# Altium schematic sheet Sensor_Box_CAN_Switch.SchDoc
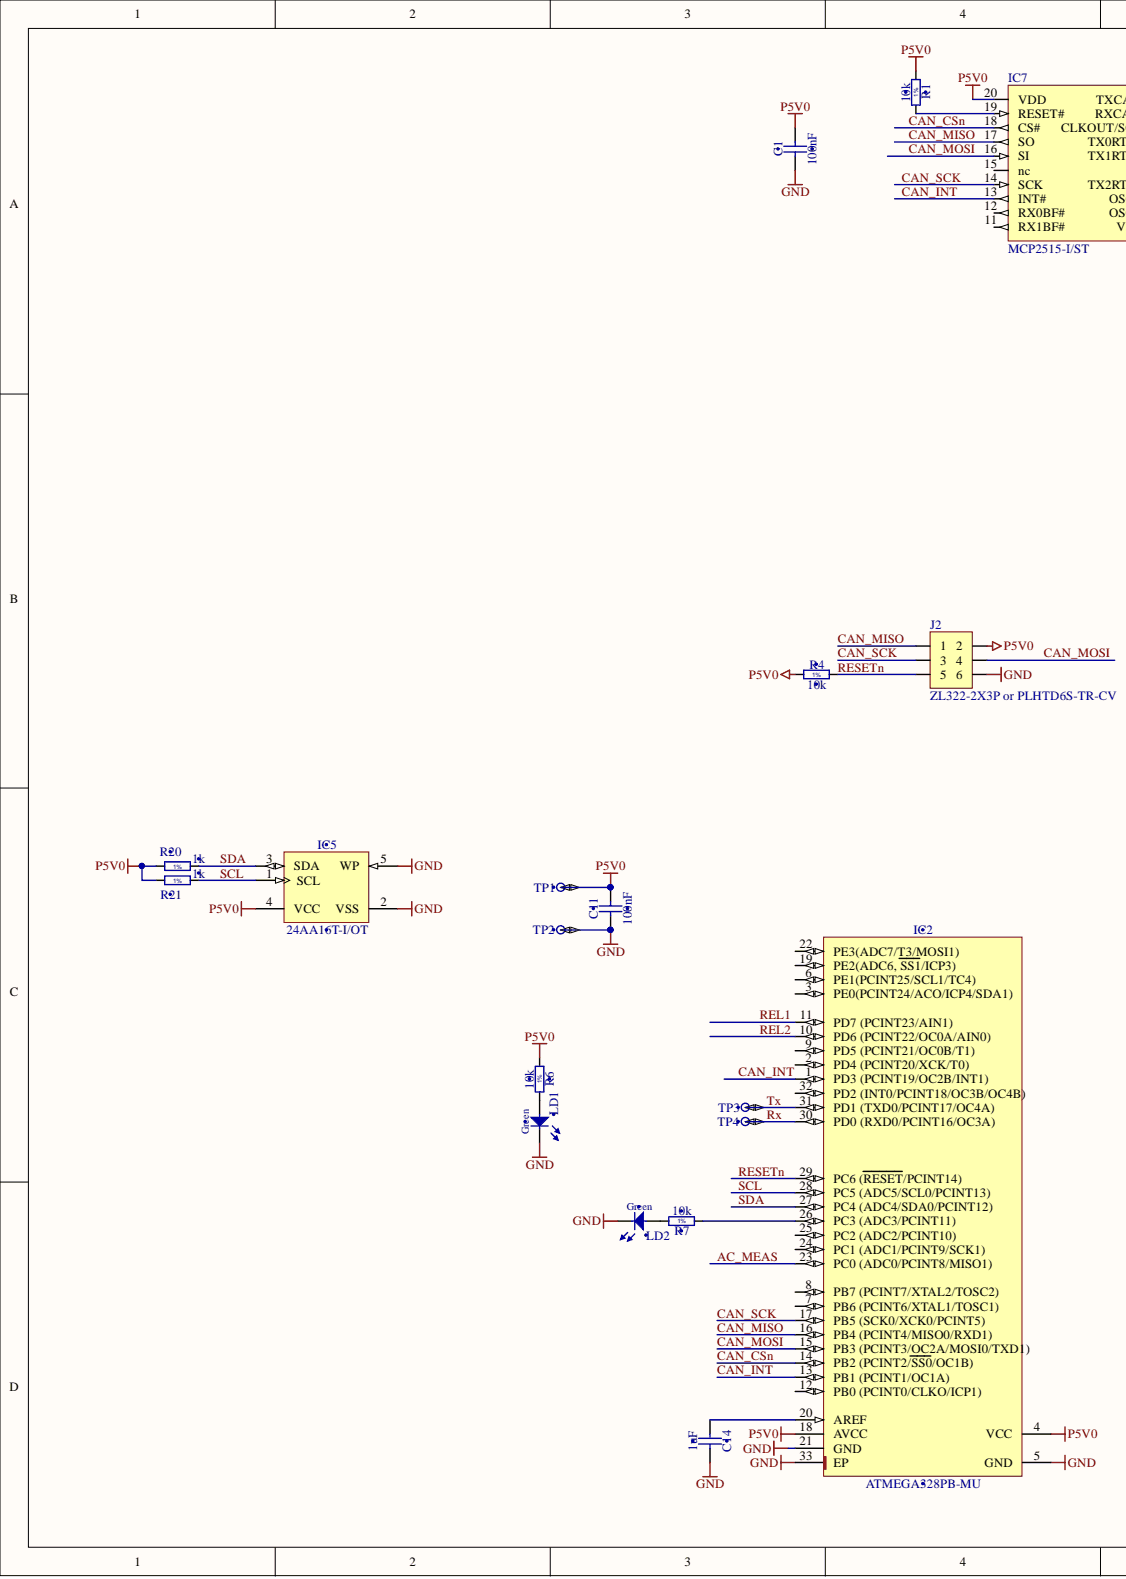
[diagram p0: Sensor_Box_CAN_Switch.SchDoc, left]
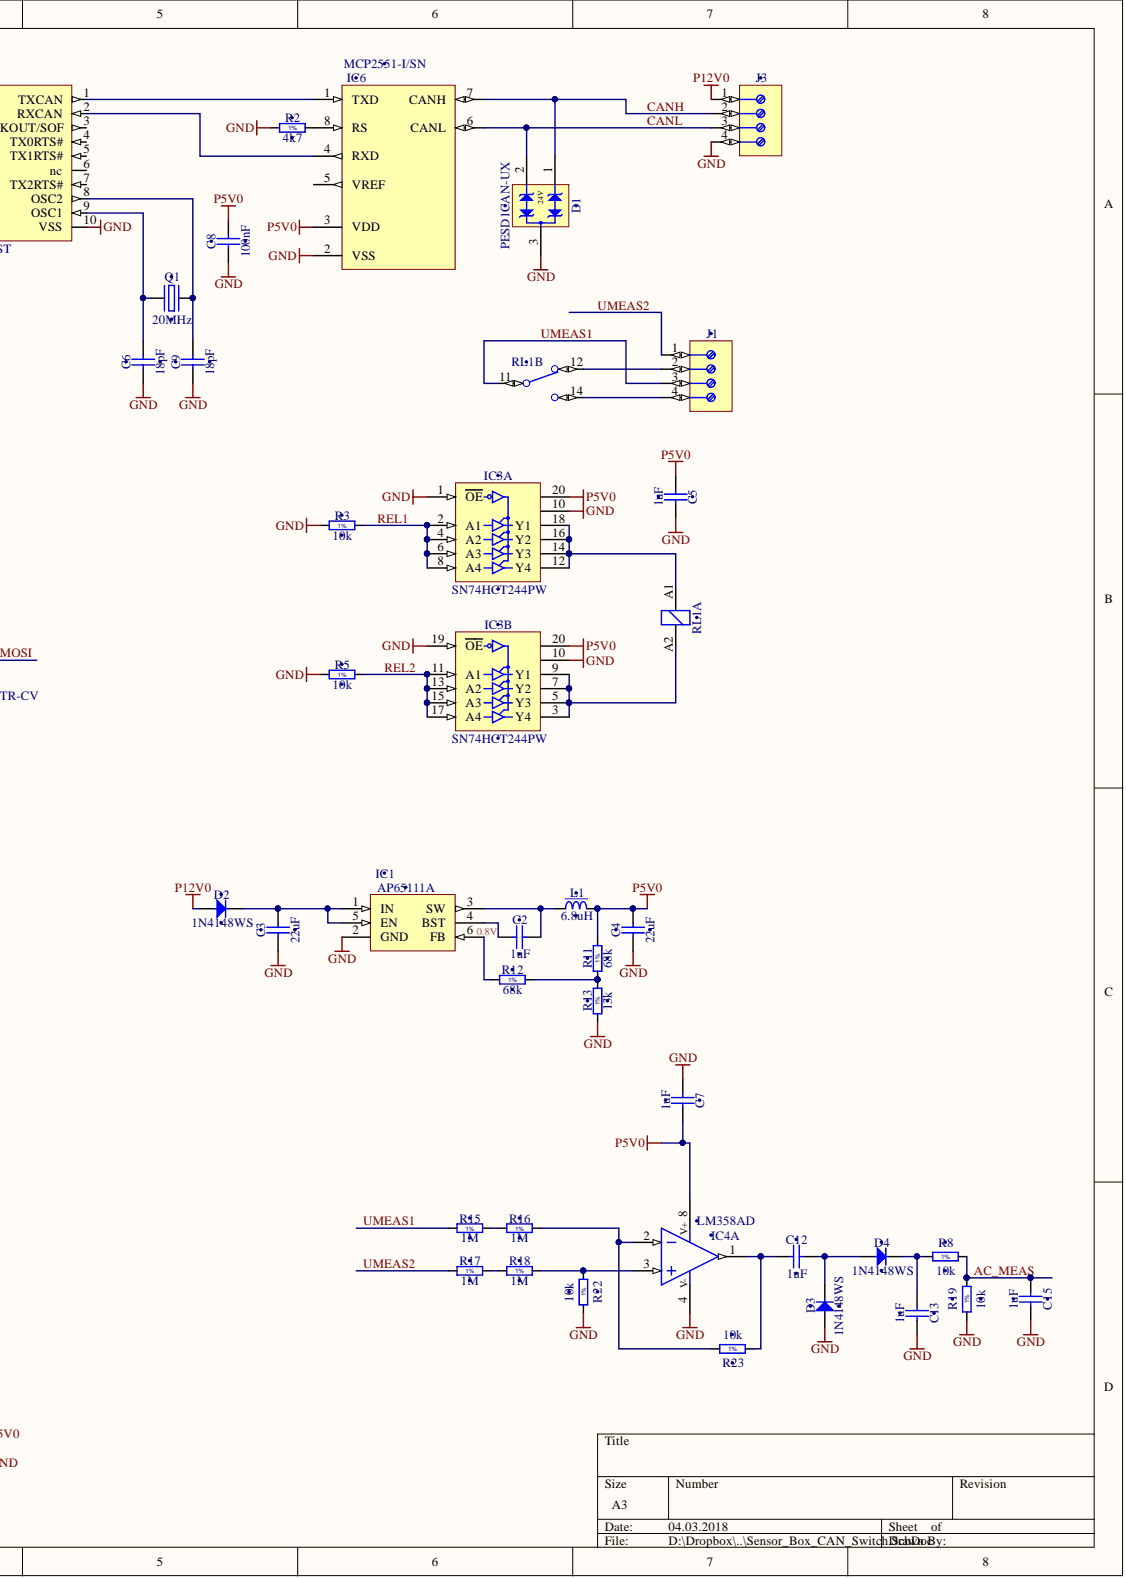
[diagram p1: Sensor_Box_CAN_Switch.SchDoc, right]

## Parts
C1  100nF  [CAPC1005X55N]
C2  1uF  [CAPC1608X87N]
C3  22uF  [CAPC1709X100N]
C4  22uF  [CAPC1709X100N]
C5  1uF  [CAPC1005X55N]
C6  18pF  [CAPC1005X55N]
C7  1uF  [CAPC1005X55N]
C8  100nF  [CAPC1005X55N]
C9  18pF  [CAPC1005X55N]
C11  100nF  [CAPC1005X55N]
C12  1uF  [CAPC1005X55N]
C13  1uF  [CAPC1005X55N]
C14  1uF  [CAPC1005X55N]
C15  1uF  [CAPC1005X55N]
D1  PESD1CAN-UX  Voltage: 24V  [SOT95P237X112-3N]
D2  1N4148WS  [SODFL2512X100N]
D3  1N4148WS  [SODFL2512X100N]
D4  1N4148WS  [SODFL2512X100N]
IC1  AP65111A  [SOT95P285X110-6N]
IC2  ATMEGA328PB-MU  [QFN50P500X500X100-33N-S325]
IC3  SN74HCT244PW  [SOP65P640X120-20N]  (parts A,B)
IC4  LM358AD  [SOIC127P600X175-8N]  (part A)
IC5  24AA16T-I/OT  [SOT95P280X145-5N]
IC6  MCP2551-I/SN  [SOIC127P600X175-8N]
IC7  MCP2515-I/ST  [SOP65P640X110-20N]
J1  Family  [TYCO_282836-4]  (value not drawn)
J2  ZL322-2X3P or PLHTD6S-TR-CV  [ZL322-2X3P]
J3  Family  [PHOENIX_1725672]  (value not drawn)
L1  6.8uH  [IND_WALSIN_WLPN3030]
LD1  Green  [LED_KINGBRIGHT_KPH-1608CGCK]
LD2  Green  [LED_KINGBRIGHT_KPH-1608CGCK]
Q1  20MHz  [XTAL1160X490X430]
R1  10k  [RESC1005X40N]
R2  4k7  [RESC1005X40N]
R3  10k  [RESC1005X40N]
R4  10k  [RESC1005X40N]
R5  10k  [RESC1005X40N]
R6  10k  [RESC1005X40N]
R7  10k  [RESC1005X40N]
R8  10k  [RESC1005X40N]
R11  68k  [RESC1005X40N]
R12  68k  [RESC1005X40N]
R13  13k  [RESC1005X40N]
R15  1M  [RESC3216X65N]
R16  1M  [RESC3216X65N]
R17  1M  [RESC3216X65N]
R18  1M  [RESC3216X65N]
R19  10k  [RESC1005X40N]
R20  1k  [RESC1005X40N]
R21  1k  [RESC1005X40N]
R22  10k  [RESC1005X40N]
R23  10k  [RESC1005X40N]
RL1  REL_TYCO_SCHRACK_PE014F05  [REL_TYCO_SCHRACK_PE]  (value not drawn)  (parts A,B)
TP1  Test Point  [TPS127]  (value not drawn)  (no BOM)
TP2  Test Point  [TPS127]  (value not drawn)  (no BOM)
TP3  Test Point  [TPS127]  (value not drawn)  (no BOM)
TP4  Test Point  [TPS127]  (value not drawn)  (no BOM)

## Nets
GND: C1.1 C3.1 C4.1 C5.2 C6.1 C7.1 C8.1 C9.1 C11.1 C13.2 C14.2 C15.2 D1.3 D3.2 IC1.2(GND) IC2.5(GND) IC2.21(GND) IC2.33(EP) IC3.1(OE) IC3.10(GND) IC3.19(OE) IC4.4 IC5.2(VSS) IC5.5(WP) IC6.2(VSS) IC7.10(VSS) J2.6 J3.4 LD1.1 LD2.1 R2.1 R3.1 R5.1 R13.1 R19.1 R22.2 TP2.1
P5V0: C1.2 C4.2 C5.1 C7.2 C8.2 C11.2 IC2.4(VCC) IC2.18(AVCC) IC3.20(VCC) IC4.8 IC5.4(VCC) IC6.3(VDD) IC7.20(VDD) J2.2 L1.2 R1.1 R4.1 R6.1 R11.2 R20.2 R21.2 TP1.1
P12V0: D2.2 J3.1
AC_MEAS: C15.1 IC2.23(PC0_(ADC0/PCINT8/MISO1)) R8.2 R19.2
CAN_CSn: IC2.14(PB2_(PCINT2/SS0/OC1B)) IC7.18(CS#)
CAN_INT: IC2.1(PD3_(PCINT19/OC2B/INT1)) IC2.13(PB1_(PCINT1/OC1A)) IC7.13(INT#)
CAN_MISO: IC2.16(PB4_(PCINT4/MISO0/RXD1)) IC7.17(SO) J2.1
CAN_MOSI: IC2.15(PB3_(PCINT3/OC2A/MOSI0/TXD1)) IC7.16(SI) J2.4
CAN_SCK: IC2.17(PB5_(SCK0/XCK0/PCINT5)) IC7.14(SCK) J2.3
CANH: D1.1 IC6.7(CANH) J3.2
CANL: D1.2 IC6.6(CANL) J3.3
REL1: IC2.11(PD7_(PCINT23/AIN1)) IC3.2(A1) IC3.4(A2) IC3.6(A3) IC3.8(A4) R3.2
REL2: IC2.10(PD6_(PCINT22/OC0A/AIN0)) IC3.11(A1) IC3.13(A2) IC3.15(A3) IC3.17(A4) R5.2
RESETn: IC2.29(PC6_(RESET/PCINT14)) J2.5 R4.2
Rx: IC2.30(PD0_(RXD0/PCINT16/OC3A)) TP4.1
SCL: IC2.28(PC5_(ADC5/SCL0/PCINT13)) IC5.1(SCL) R21.1
SDA: IC2.27(PC4_(ADC4/SDA0/PCINT12)) IC5.3(SDA) R20.1
Tx: IC2.31(PD1_(TXD0/PCINT17/OC4A)) TP3.1
UMEAS1: J1.3 R15.1 RL1.11
UMEAS2: J1.1 R17.1
NetC2_1: C2.1 IC1.4(BST)
NetC2_2: C2.2 IC1.3(SW) L1.1
NetC3_2: C3.2 D2.1 IC1.1(IN) IC1.5(EN)
NetC6_2: C6.2 IC7.9(OSC1) Q1.1
NetC9_2: C9.2 IC7.8(OSC2) Q1.2
NetC12_1: C12.1 IC4.1 R23.1
NetC12_2: C12.2 D3.1 D4.2
NetC13_1: C13.1 D4.1 R8.1
NetC14_1: C14.1 IC2.20(AREF)
NetIC1_6: IC1.6(FB) R12.1
NetIC2_26: IC2.26(PC3_(ADC3/PCINT11)) R7.1
NetIC3_3: IC3.3(Y4) IC3.5(Y3) IC3.7(Y2) IC3.9(Y1) RL1.A2
NetIC3_12: IC3.12(Y4) IC3.14(Y3) IC3.16(Y2) IC3.18(Y1) RL1.A1
NetIC4_2: IC4.2 R16.2 R23.2
NetIC4_3: IC4.3 R18.2 R22.1
NetIC6_1: IC6.1(TXD) IC7.1(TXCAN)
NetIC6_4: IC6.4(RXD) IC7.2(RXCAN)
NetIC6_8: IC6.8(RS) R2.2
NetIC7_19: IC7.19(RESET#) R1.2
NetJ1_2: J1.2 RL1.12
NetJ1_4: J1.4 RL1.14
NetLD1_2: LD1.2 R6.2
NetLD2_2: LD2.2 R7.2
NetR11_1: R11.1 R12.2 R13.2
NetR15_2: R15.2 R16.1
NetR17_2: R17.2 R18.1

Not connected: IC2 2(PD4_(PCINT20/XCK/T0)) 3(PE0(PCINT24/ACO/ICP4/SDA1)) 6(PE1(PCINT25/SCL1/TC4)) 7(PB6_(PCINT6/XTAL1/TOSC1)) 8(PB7_(PCINT7/XTAL2/TOSC2)) 9(PD5_(PCINT21/OC0B/T1)) 12(PB0_(PCINT0/CLKO/ICP1)) 19(PE2(ADC6,_SS1/ICP3)) 22(PE3(ADC7/T3/MOSI1)) 24(PC1_(ADC1/PCINT9/SCK1)) 25(PC2_(ADC2/PCINT10)) 32(PD2_(INT0/PCINT18/OC3B/OC4B)); IC6 5(VREF); IC7 3(CLKOUT/SOF) 4(TX0RTS#) 5(TX1RTS#) 6(nc) 7(TX2RTS#) 11(RX1BF#) 12(RX0BF#) 15(nc)

## Library data of the parts
C1 C8 C11 = CC0402_100NF_16V_10%_X5R: Non-polarised capacitor; Manufacturer: GENERIC; Manufacturer1 Part Number: GRM155R61C104KA88D; Part Description: SMD Multilayer Chip Ceramic Capacitor; Database Table Name: Capacitors SMD; TC: X5R; Tolerance: ±10%; Voltage: 16V; Case: 0402; Component Kind: Standard; Component Type: Standard; CreateDate: 10.12.2013; HelpURL: \\cern.ch\dfs\Applications\Altium\Datasheets\CC0402_X5R_MURATA_GRM.pdf; LatestRevisionDate: 10.12.2013; Library Name: Capacitors.DbLib; Manufacturer1 ComponentHeight: 0.55mm; Manufacturer1 Example: MURATA; Manufacturer Part Number: CC0402_100NF_16V_10%_X5R; Mounted: Yes; Part Number: CC0402_100NF_16V_10%_X5R; Pin Count: 2; Sense: No; SMD: Yes; Socket: No; Status: None; Value: 100nF; Author: CERN DEM JLC
C2 = CC0603_1UF_16V_10%_X7R: Non-polarised capacitor; Manufacturer: GENERIC; Manufacturer1 Part Number: 223878615663; Part Description: SMD Multilayer Chip Ceramic Capacitor; Database Table Name: Capacitors SMD; TC: X7R; Tolerance: ±10%; Voltage: 16V; Case: 0603; Component Kind: Standard; Component Type: Standard; CreateDate: 03.12.2007; HelpURL: \\cern.ch\dfs\Applications\Altium\Datasheets\CC0603_X7R_PHYCOMP.pdf; LatestRevisionDate: 17.04.2015; Library Name: Capacitors.DbLib; Manufacturer1 ComponentHeight: 0.9mm; Manufacturer1 Example: YAGEO PHYCOMP; Manufacturer Part Number: CC0603_1uF_16V_10%_X7R; Mounted: Yes; Part Number: CC0603_1UF_16V_10%_X7R; Pin Count: 2; Sense: No; SMD: Yes; Socket: No; Status: None; Value: 1uF; Author: CERN DEM JLC
C3 C4 = CC0603_22UF_6.3V_20%_X5R: Non-polarised capacitor; Manufacturer: GENERIC; Manufacturer1 Part Number: C1608X5R0J226M080AC; Part Description: SMD Multilayer Chip Ceramic Capacitor; Database Table Name: Capacitors SMD; TC: X5R; Tolerance: ±20%; Voltage: 6.3V; Case: 0603; Component Kind: Standard; Component Type: Standard; CreateDate: 16.12.2013; HelpURL: \\cern.ch\dfs\Applications\Altium\Datasheets\CC0603_X5R_TDK_C.pdf; LatestRevisionDate: 13.09.2016; Library Name: Capacitors.DbLib; Manufacturer1 ComponentHeight: 1mm; Manufacturer1 Example: TDK; Manufacturer Part Number: CC0603_22UF_6.3V_20%_X5R; Mounted: Yes; Part Number: CC0603_22UF_6.3V_20%_X5R; Pin Count: 2; Sense: No; SMD: Yes; Socket: No; Status: None; Value: 22uF; Author: CERN DEM JLC
C5 C7 C12-C15 = CC0402_1UF_16V_10%_X5R: Non-polarised capacitor; Manufacturer: GENERIC; Manufacturer1 Part Number: C1005X5R1C105KT; Part Description: SMD Multilayer Chip Ceramic Capacitor; Database Table Name: Capacitors SMD; TC: X5R; Tolerance: ±10%; Voltage: 16V; Case: 0402; Component Kind: Standard; Component Type: Standard; CreateDate: 25.10.2012; HelpURL: \\cern.ch\dfs\Applications\Altium\Datasheets\CC0402_X5R_TDK_C.pdf; LatestRevisionDate: 25.10.2012; Library Name: Capacitors.DbLib; Manufacturer1 ComponentHeight: 0.55mm; Manufacturer1 Example: TDK; Manufacturer Part Number: CC0402_1UF_16V_10%_X5R; Mounted: Yes; Part Number: CC0402_1UF_16V_10%_X5R; Pin Count: 2; Sense: No; SMD: Yes; Socket: No; Status: Preferred; Value: 1uF; Author: CERN DEM JLC
C6 C9 = CC0402_18PF_50V_5%_C0G: Non-polarised capacitor; Manufacturer: GENERIC; Manufacturer1 Part Number: C0402C180J5GACTU; Part Description: SMD Multilayer Chip Ceramic Capacitor; Database Table Name: Capacitors SMD; TC: C0G/NP0; Tolerance: ±5%; Voltage: 50V; Case: 0402; Component Kind: Standard; Component Type: Standard; CreateDate: 04.10.2016; HelpURL: \\cern.ch\dfs\Applications\Altium\Datasheets\CC0805_C0G_KEMET_(Commercial Grade).pdf; LatestRevisionDate: 04.10.2016; Library Name: Capacitors.DbLib; Manufacturer1 ComponentHeight: 0.55mm; Manufacturer1 Example: KEMET; Manufacturer Part Number: CC0402_18PF_50V_5%_C0G; Mounted: Yes; Part Number: CC0402_18PF_50V_5%_C0G; Pin Count: 2; Sense: No; SMD: Yes; Socket: No; Status: Preferred; Value: 18pF; Author: CERN DEM JLC
D1 = (no symbol name): TVS Diode for CAN; Manufacturer: Nexperia; Bonding: No; Power: 400W; PressFit: No; Case: SOT-23; ComponentHeight: 1.12mm; Component Kind: Standard; Component Type: Standard; CreateDate: 03.09.2014; Device: PESD1CAN-UX; HelpURL: \\cern.ch\dfs\Applications\Altium\Datasheets\SM712.TCT.pdf; LatestRevisionDate: 13.01.2015; Library Name: LIBRARY_SCH.SCHLIB; Manufacturer Part Number: PESD1CAN-UX; Mounted: Yes; PackageDescription: SOT, 0.95mm, pitch; 3 pin,1.30mm W X 2.92mm L X 1.12mm H body, IPC Medium Density; Part Description: TVS Diode for CAN; Part Number: PESD1CAN-UX; Pin Count: 3; Sense: No; SMD: Yes; Socket: No; Author: CERN DEM JLC
D2-D4 = 1N4148WS: Diode; Manufacturer: FAIRCHILD SEMICONDUCTOR; Part Description: Small Signal Diodes; Bonding: No; Database Table Name: Diodes; Power: 150mA; PressFit: No; Voltage: 75V; Case: SOD-323F; ComponentHeight: 1mm; Component Kind: Standard; Component Type: Standard; CreateDate: 12.05.2011; Device: 1N4148WS; HelpURL: \\cern.ch\dfs\Applications\Altium\Datasheets\1N4148WS.pdf; LatestRevisionDate: 12.05.2011; Library Name: ICs And Semiconductors.DbLib; Manufacturer Part Number: 1N4148WS; Mounted: Yes; PackageDescription: SODFL Diode; 1.70mm W X 1.25mm L X 1.00mm H body, IPC Medium Density; Part Number: 1N4148WS; Pin Count: 2; Sense: No; SMD: Yes; Socket: No; Author: CERN DEM JLC
IC1 = (no symbol name): LIGHT LOAD IMPROVED 1.5A SYNCH DC/DC BUCK CONVERTER; Manufacturer: DIODES INCORPORATED; Bonding: No; PressFit: No; Case: SOT26; ComponentHeight: 1.45mm; Component Kind: Standard; Component Type: Standard; CreateDate: 10.07.2015; Device: AP65111A; HelpURL: \\cern.ch\dfs\Applications\Altium\Datasheets\AP133-WG-7.pdf; LatestRevisionDate: 10.07.2015; Library Name: LIBRARY_SCH.SCHLIB; Manufacturer Part Number: AP65111A; Mounted: Yes; PackageDescription: SOT23, 0.95mm pitch; 6 pin, 1.6mm W X 3.0mm L X 1.45mm H body, IPC Medium Density; Part Description: LIGHT LOAD IMPROVED 1.5A SYNCH DC/DC BUCK CONVERTER; Part Number: AP65111A; Pin Count: 5; Sense: No; SMD: Yes; Socket: No; Author: GK
IC2 = (no symbol name): 8-bit AVR 20MHz Microcontroller With 32K Bytes In-System  Programmable  Flash; Manufacturer: ATMEL; Part Description: 8-bit AVR Microcontroller, 32KB Flash, 1KB EEPROM, 2KB SRAM, 32-pin MLF, Industrial Grade (-40°C to 85°C); Bonding: No; PressFit: No; Case: QFN32; ComponentHeight: 1mm; Component Kind: Standard; Component Type: Standard; CreateDate: 2011-02-08; Device: ATMEGA328PB-MU; HelpURL: \\cern.ch\dfs\Applications\Altium\Datasheets\ATMEGA328P-MU.pdf; LatestRevisionDate: 2014-07-02; Library Name: LIBRARY_SCH.SCHLIB; Manufacturer Part Number: ATMEGA328PB-MU; Mounted: Yes; PackageDescription: QFN, (MO-220-VHHD-2) 0.50mm pitch,square; 8 pin X 8 pin, 5mm X 5mm X 1.00mm H body (w/thermal tab 3.25 X 3.25mm),  IPC Medium Density; Part Number: ATMEGA328PB-MU; Pin Count: 33; Sense: No; SMD: Yes; Socket: No; Author: GK
IC3 = SN74HCT244PW: Octal Buffer/Driver with 3-State Ouputs; Manufacturer: TEXAS INSTRUMENTS; Part Description: Octal Buffer And Line Driver with 3-State Ouputs; Bonding: No; Database Table Name: Standard Logic; PressFit: No; Case: TSSOP20; ComponentHeight: 1.2mm; Component Kind: Standard; Component Type: Standard; CreateDate: 11.10.2011; Device: SN74HCT244PW; HelpURL: \\cern.ch\dfs\Applications\Altium\Datasheets\SN74HCT244PW.pdf; LatestRevisionDate: 11.10.2011; Library Name: ICs And Semiconductors.DbLib; Manufacturer Part Number: SN74HCT244PW; Mounted: Yes; PackageDescription: SOP, 20-Leads, Body 6.5x4.4mm, Pitch 0.65mm, IPC Medium Density; Part Number: SN74HCT244PW; Pin Count: 20; Sense: No; SMD: Yes; Socket: No; Author: CERN DEM JLC
IC4 = LM358AD: Dual Operational Amplifier; Manufacturer: TEXAS INSTRUMENTS; Bonding: No; Database Table Name: Operational Amplifiers; PressFit: No; Case: SOIC8; ComponentHeight: 1.75mm; Component Kind: Standard; Component Type: Standard; CreateDate: 02.12.2008; Device: LM358AD; HelpURL: \\cern.ch\dfs\Applications\Altium\Datasheets\LM358AD.pdf; LatestRevisionDate: 13.04.2010; Library Name: ICs And Semiconductors.DbLib; Manufacturer Part Number: LM358AD; Mounted: Yes; PackageDescription: SOIC 8, Pitch 1.27mm - Body 4x5mm, IPC Medium Density; Part Description: Dual Operational Amplifier; Part Number: LM358AD; Pin Count: 8; Sense: No; SMD: Yes; Socket: No; Author: CERN DEM JLC
IC5 = (no symbol name): 1.7V-5.5V, 2K I2C Serial EEPROM With EUI-48 Node Identity; Manufacturer: MICROCHIP TECHNOLOGY; Part Description: 1.7V-5.5V, 16K I2C Serial EEPROM; Bonding: No; PressFit: No; Case: SOT23-5; ComponentHeight: 1.45mm; Component Kind: Standard; Component Type: Standard; CreateDate: 31.03.2016; Device: 24AA16T-I/OT; HelpURL: \\cern.ch\dfs\Applications\Altium\Datasheets\24AA02E48T-I-OT.pdf; LatestRevisionDate: 31.03.2016; Library Name: LIBRARY_SCH.SCHLIB; Manufacturer Part Number: 24AA16T-I/OT; Mounted: Yes; PackageDescription: SOT, 0.95mm pitch; 5 pin, 1.60mm W X 2.90mm L X 1.45mm H body, IPC Medium Density; Part Number: 24AA16T-I/OT; Pin Count: 5; Sense: No; SMD: Yes; Socket: No; Author: GK
IC6 = (no symbol name): High-Speed CAN Transceiver, 8-Pin SOIC, Industrial Temperature; Manufacturer: MICROCHIP TECHNOLOGY; Bonding: No; PressFit: No; Case: SOIC8; ComponentHeight: 1.75mm; Component Kind: Standard; Component Type: Standard; CreateDate: 07.09.2016; Device: MCP2551-I/SN; LatestRevisionDate: 07.09.2016; Library Name: LIBRARY_SCH.SCHLIB; Manufacturer Part Number: MCP2551-I/SN; Mounted: Yes; PackageDescription: SOIC 8, Pitch 1.27mm - Body 4x5mm, IPC Medium Density; Part Description: High-Speed CAN Transceiver; Part Number: MCP2551-I/SN; Pin Count: 8; Sense: No; SMD: Yes; Socket: No; Author: GK
IC7 = IFC_MCP2515_TSSOP: CAN Controller With SPI nterface; Manufacturer: Microchip; Manufacturer Part Number: MCP2515T-I/ST; Part Description: Stand-Alone CAN Controller with SPI Interface; Case: TSSOP20; Component Kind: Standard; Component Type: Standard; Device: MCP2515; Library Name: LIBRARY_SCH.SCHLIB; Mounted: Yes; PackageDescription: TSSOP 20, Pitch 0.65mm - Body 4.5x6.5mm, IPC Medium Density; Part Number: MCP2515T-I/ST; Pin Count: 20; SMD: Yes
J1 = TYCO_282836-4: Terminal Block, 4 Positions, Screw Connection; Manufacturer: TYCO ELECTRONICS; Part Description: 15.5A, 300V, Terminal Block, Pitch 5mm, 4 Positions, Screw Connection; Database Table Name: TYCO; PressFit: No; ComponentHeight: 10mm; Component Kind: Standard; Component Type: Standard; CreateDate: 10.11.2014; HelpURL: \\cern.ch\dfs\Applications\Altium\Datasheets\TYCO_282836-4.pdf; LatestRevisionDate: 10.11.2014; Library Name: Connectors.DbLib; Manufacturer Part Number: 282836-4; Mounted: Yes; Part Number: TYCO_282836-4; Pin Count: 4; Sense: No; SMD: No; Socket: No; Status: None; Author: CERN DEM JLC
J2 = CON_2x3 1.27 SMT Male: 1.27 mm dual row goldpin (Maritex); Component Kind: Standard; Library Name: LIBRARY_SCH.SCHLIB
J3 = PHOENIX_1725672: Terminal Block, 4 Positions, Screw Connection; Manufacturer: PHOENIX CONTACT; Manufacturer Part Number: 1725672 (MPT 0,5/4-2,54); Part Description: 6A, 63V, Terminal Block, Pitch 2.54mm, 4 Positions, Screw Connection; PressFit: No; ComponentHeight: 8.5mm; Component Kind: Standard; Component Type: Standard; CreateDate: 07.11.2008; Database Table Name: PHOENIX; HelpURL: \\cern.ch\dfs\Applications\Altium\Datasheets\PHOENIX_1725672.pdf; LatestRevisionDate: 26.04.2010; Library Name: Connectors.DbLib; Mounted: Yes; Part Number: PHOENIX_1725672; Pin Count: 4; Sense: No; SMD: No; Socket: No; Status: None; Author: CERN DEM JMW
L1 = (no symbol name): 6,8uH; 900mA; 0,211Ω; 3x3x1,5mm; Manufacturer: WALSIN; Manufacturer Part Number: WLPN303015M6R8PB; Part Description: Miniature Surface Mount Power Inductor (8200 Series); Part Number: IND_6.8UH_20%_WALSIN_WLPN303015M6R8PB; Resistance: 0.21R; Tolerance: ±20%; ComponentHeight: 1.5; Component Kind: Standard; Component Type: Standard; CreateDate: 11.07.2016; HelpURL: \\cern.ch\dfs\Applications\Altium\Datasheets\IND_MURATA-PS_8200.pdf; LatestRevisionDate: 11.07.2016; Library Name: LIBRARY_SCH.SCHLIB; Mounted: Yes; Pin Count: 2; Power: 900mA; Sense: No; SMD: Yes; Socket: No; Status: None; Value: 6.8uH; Author: GK
LD1 LD2 = LED_KINGBRIGHT_KPH-1608CGCK: LED Green 1C 2A; Part Description: SMD Green LED, Case 1.6mmX0.8mm(0603), 0.65mm Thickness; Bonding: No; Database Table Name: LEDs & Displays; PressFit: No; Color: Green; ComponentHeight: 0.65mm; Component Kind: Standard; Component Type: Standard; CreateDate: 03.07.2017; HelpURL: \\cern.ch\dfs\Applications\Altium\Datasheets\LED_KINGBRIGHT_KPH-1608CGCK.pdf; LatestRevisionDate: 03.07.2017; Library Name: ICs And Semiconductors.DbLib; Manufacturer: KINGBRIGHT; Manufacturer Part Number: KPH-1608CGCK; Mounted: Yes; PackageDescription: SMT Green LED, Case 0603, Body L 1.6 x W 0.8mm H 0.65mm; Part Number: LED_KINGBRIGHT_KPH-1608CGCK; Pin Count: 2; Sense: No; SMD: Yes; Socket: No; Author: CERN DEM JLC
Q1 = (no symbol name): Quartz Crystal 20MHz, 30ppm, 20pF; Manufacturer: SR PASSIVES; Manufacturer Part Number: 20M-49SMD-SR; Part Description: Tolerance:± 30ppm, Stability:± 50ppm, -10°C to +60°C, 20pF, Surface Mount Quartz Crystal, HC49/4HSMX Series; Part Number: SR PASSIVES 20M-49SMD-SR; Bonding: No; PressFit: No; ComponentHeight: 4.3mm; Component Kind: Standard; Component Type: Standard; CreateDate: 19.04.2012; HelpURL: \\cern.ch\dfs\Applications\Altium\Datasheets\XTAL_IQD_LFXTAL003166.pdf; LatestRevisionDate: 07.11.2016; Library Name: LIBRARY_SCH.SCHLIB; Mounted: Yes; PackageDescription: HC49/4H SMX Case, SMD Cristal, Body 11.6x4.9mm; Pin Count: 2; Sense: No; SMD: Yes; Socket: No; Value: 20MHz; Author: GK
R1 R3-R7 = R0402_10K_1%_0.0625W_100PPM: Resistor - 1%; Manufacturer: GENERIC; Manufacturer1 Part Number: 232270671003L; Part Description: General Purpose Thick Film Chip Resistor; Database Table Name: Resistors SMD; PressFit: No; TC: ±100ppm/°C; Case: 0402; Component Kind: Standard; Component Type: Standard; CreateDate: 03.12.2007; HelpURL: \\cern.ch\dfs\Applications\Altium\Datasheets\R0402_Phycomp_RC0402.pdf; LatestRevisionDate: 17.10.2012; Library Name: Resistors.DbLib; Manufacturer1 ComponentHeight: 0.4mm; Manufacturer1 Example: YAGEO PHYCOMP; Manufacturer Part Number: R0402_10K_1%_0.0625W_100PPM; Mounted: Yes; Part Number: R0402_10K_1%_0.0625W_100PPM; Pin Count: 2; Power: 0.0625W; Sense: No; SMD: Yes; Socket: No; Status: Not Recommended; Tolerance: ±1%; Value: 10k; Author: CERN DEM JLC
R2 = R0402_4K7_1%_0.0625W_100PPM: Resistor - 1%; Manufacturer: GENERIC; Manufacturer1 Part Number: 232270674702L; Part Description: General Purpose Thick Film Chip Resistor; Database Table Name: Resistors SMD; PressFit: No; TC: ±100ppm/°C; Case: 0402; Component Kind: Standard; Component Type: Standard; CreateDate: 03.12.2007; HelpURL: \\cern.ch\dfs\Applications\Altium\Datasheets\R0402_Phycomp_RC0402.pdf; LatestRevisionDate: 17.10.2012; Library Name: Resistors.DbLib; Manufacturer1 ComponentHeight: 0.4mm; Manufacturer1 Example: YAGEO PHYCOMP; Manufacturer Part Number: R0402_4K7_1%_0.0625W_100PPM; Mounted: Yes; Part Number: R0402_4K7_1%_0.0625W_100PPM; Pin Count: 2; Power: 0.0625W; Sense: No; SMD: Yes; Socket: No; Status: Not Recommended; Tolerance: ±1%; Value: 4k7; Author: CERN DEM JLC
R8 R19 R22 R23 = R0402_10K_1%_0.0625W_100PPM: Resistor - 1%; Manufacturer: GENERIC; Manufacturer1 Part Number: 232270671003L; Part Description: General Purpose Thick Film Chip Resistor; Database Table Name: Resistors SMD; TC: ±100ppm/°C; Case: 0402; Component Kind: Standard; Component Type: Standard; CreateDate: 2007-12-03; HelpURL: \\cern.ch\dfs\Applications\Altium\Datasheets\R0402_Phycomp_RC0402.pdf; LatestRevisionDate: 2012-10-17; Library Name: Resistors.DbLib; Manufacturer1 ComponentHeight: 0.4mm; Manufacturer1 Example: YAGEO PHYCOMP; Manufacturer Part Number: R0402_10K_1%_0.0625W_100PPM; Mounted: Yes; Part Number: R0402_10K_1%_0.0625W_100PPM; Pin Count: 2; Power: 0.0625W; SMD: Yes; Socket: No; Status: Not recommended; Table: Resistors SMD; Tolerance: ±1%; Value: 10k; Author: CERN DEM JLC
R11 R12 = R0402_68K_1%_0.0625W_100PPM: Resistor - 1%; Manufacturer: GENERIC; Manufacturer1 Part Number: 232270676803L; Part Description: General Purpose Thick Film Chip Resistor; Database Table Name: Resistors SMD; PressFit: No; TC: ±100ppm/°C; Case: 0402; Component Kind: Standard; Component Type: Standard; CreateDate: 03.12.2007; HelpURL: \\cern.ch\dfs\Applications\Altium\Datasheets\R0402_Phycomp_RC0402.pdf; LatestRevisionDate: 17.10.2012; Library Name: Resistors.DbLib; Manufacturer1 ComponentHeight: 0.4mm; Manufacturer1 Example: YAGEO PHYCOMP; Manufacturer Part Number: R0402_68K_1%_0.0625W_100PPM; Mounted: Yes; Part Number: R0402_68K_1%_0.0625W_100PPM; Pin Count: 2; Power: 0.0625W; Sense: No; SMD: Yes; Socket: No; Status: Not Recommended; Tolerance: ±1%; Value: 68k; Author: CERN DEM JLC
R13 = R0402_13K_1%_0.0625W_100PPM: Resistor - 1%; Manufacturer: GENERIC; Manufacturer1 Part Number: 232270671303L; Part Description: General Purpose Thick Film Chip Resistor; Database Table Name: Resistors SMD; PressFit: No; TC: ±100ppm/°C; Case: 0402; Component Kind: Standard; Component Type: Standard; CreateDate: 03.12.2007; HelpURL: \\cern.ch\dfs\Applications\Altium\Datasheets\R0402_Phycomp_RC0402.pdf; LatestRevisionDate: 17.10.2012; Library Name: Resistors.DbLib; Manufacturer1 ComponentHeight: 0.4mm; Manufacturer1 Example: YAGEO PHYCOMP; Manufacturer Part Number: R0402_13K_1%_0.0625W_100PPM; Mounted: Yes; Part Number: R0402_13K_1%_0.0625W_100PPM; Pin Count: 2; Power: 0.0625W; Sense: No; SMD: Yes; Socket: No; Status: Not Recommended; Tolerance: ±1%; Value: 13k; Author: CERN DEM JLC
R15-R18 = R1206_1M_1%_0.25W_100PPM: Resistor - 1%; Manufacturer: GENERIC; Manufacturer1 Part Number: NRC12F1004TRF; Part Description: General Purpose Thick Film Chip Resistor; Database Table Name: Resistors SMD; Power: 0.25W; PressFit: No; TC: ±100ppm/°C; Case: 1206; Component Kind: Standard; Component Type: Standard; CreateDate: 03.12.2007; HelpURL: \\cern.ch\dfs\Applications\Altium\Datasheets\R1206_NIC_NRC.pdf; LatestRevisionDate: 13.03.2008; Library Name: Resistors.DbLib; Manufacturer1 ComponentHeight: 0.65mm; Manufacturer1 Example: NIC COMPONENT; Manufacturer Part Number: R1206_1M_1%_0.25W_100PPM; Mounted: Yes; Part Number: R1206_1M_1%_0.25W_100PPM; Pin Count: 2; Sense: No; SMD: Yes; Socket: No; Status: Preferred; Tolerance: ±1%; Value: 1M; Author: CERN DEM JLC
R20 R21 = R0402_1K_1%_0.0625W_100PPM: Resistor - 1%; Manufacturer: GENERIC; Manufacturer1 Part Number: 232270671002L; Part Description: General Purpose Thick Film Chip Resistor; Database Table Name: Resistors SMD; PressFit: No; TC: ±100ppm/°C; Case: 0402; Component Kind: Standard; Component Type: Standard; CreateDate: 03.12.2007; HelpURL: \\cern.ch\dfs\Applications\Altium\Datasheets\R0402_Phycomp_RC0402.pdf; LatestRevisionDate: 17.10.2012; Library Name: Resistors.DbLib; Manufacturer1 ComponentHeight: 0.4mm; Manufacturer1 Example: YAGEO PHYCOMP; Manufacturer Part Number: R0402_1K_1%_0.0625W_100PPM; Mounted: Yes; Part Number: R0402_1K_1%_0.0625W_100PPM; Pin Count: 2; Power: 0.0625W; Sense: No; SMD: Yes; Socket: No; Status: Not Recommended; Tolerance: ±1%; Value: 1k; Author: CERN DEM JLC
RL1 = (no symbol name): PE PCB Relay Form C (1 CO Contact), 1 Coil; Manufacturer: TYCO SCHRACK; Part Description: 5A SPDT Power PCB Relay PE Bistable Series; PressFit: No; Value: 5VDC; ComponentHeight: 10mm; Component Kind: Standard; Component Type: Standard; CreateDate: 28.06.2017; HelpURL: \\cern.ch\dfs\Applications\Altium\Datasheets\REL_TYCO_SCHRACK_RT314F05.pdf; LatestRevisionDate: 28.06.2017; Library Name: LIBRARY_SCH.SCHLIB; Manufacturer Part Number: PE014F05; Mounted: Yes; PackageDescription: 5 Leads, Row Spacing 5.12mm; Body 20mm x 10mm, TYCO PE Series; Part Number: REL_TYCO_SCHRACK_PE014F05; Pin Count: 5; Sense: No; SMD: No; Socket: No; Status: None; Author: GK
TP1-TP4 = SMD_PAD_1.27X1.27MM: Part Description: 1.27mm x 1.27mm PCB Copper Area (SMD Test Point); Database Table Name: Pads; Mounted: No; ComponentHeight: 0mm; Component Type: Standard (No BOM); CreateDate: 12.01.2008; Description: Test Point; Family: Test Point; HelpURL: Undefined; LatestRevisionDate: 12.01.2008; Library Name: Eletro-mechanical.DbLib; PackageDescription: 1.27mm x 1.27mm PCB Copper Area (SMD Test Point); Part Number: SMD_PAD_1.27X1.27MM; Pin Count: 1; Sense: No; SMD: Yes; Socket: No; Status: None; Author: CERN DEM JLC
